# Altium schematic sheet Rectifier.SchDoc
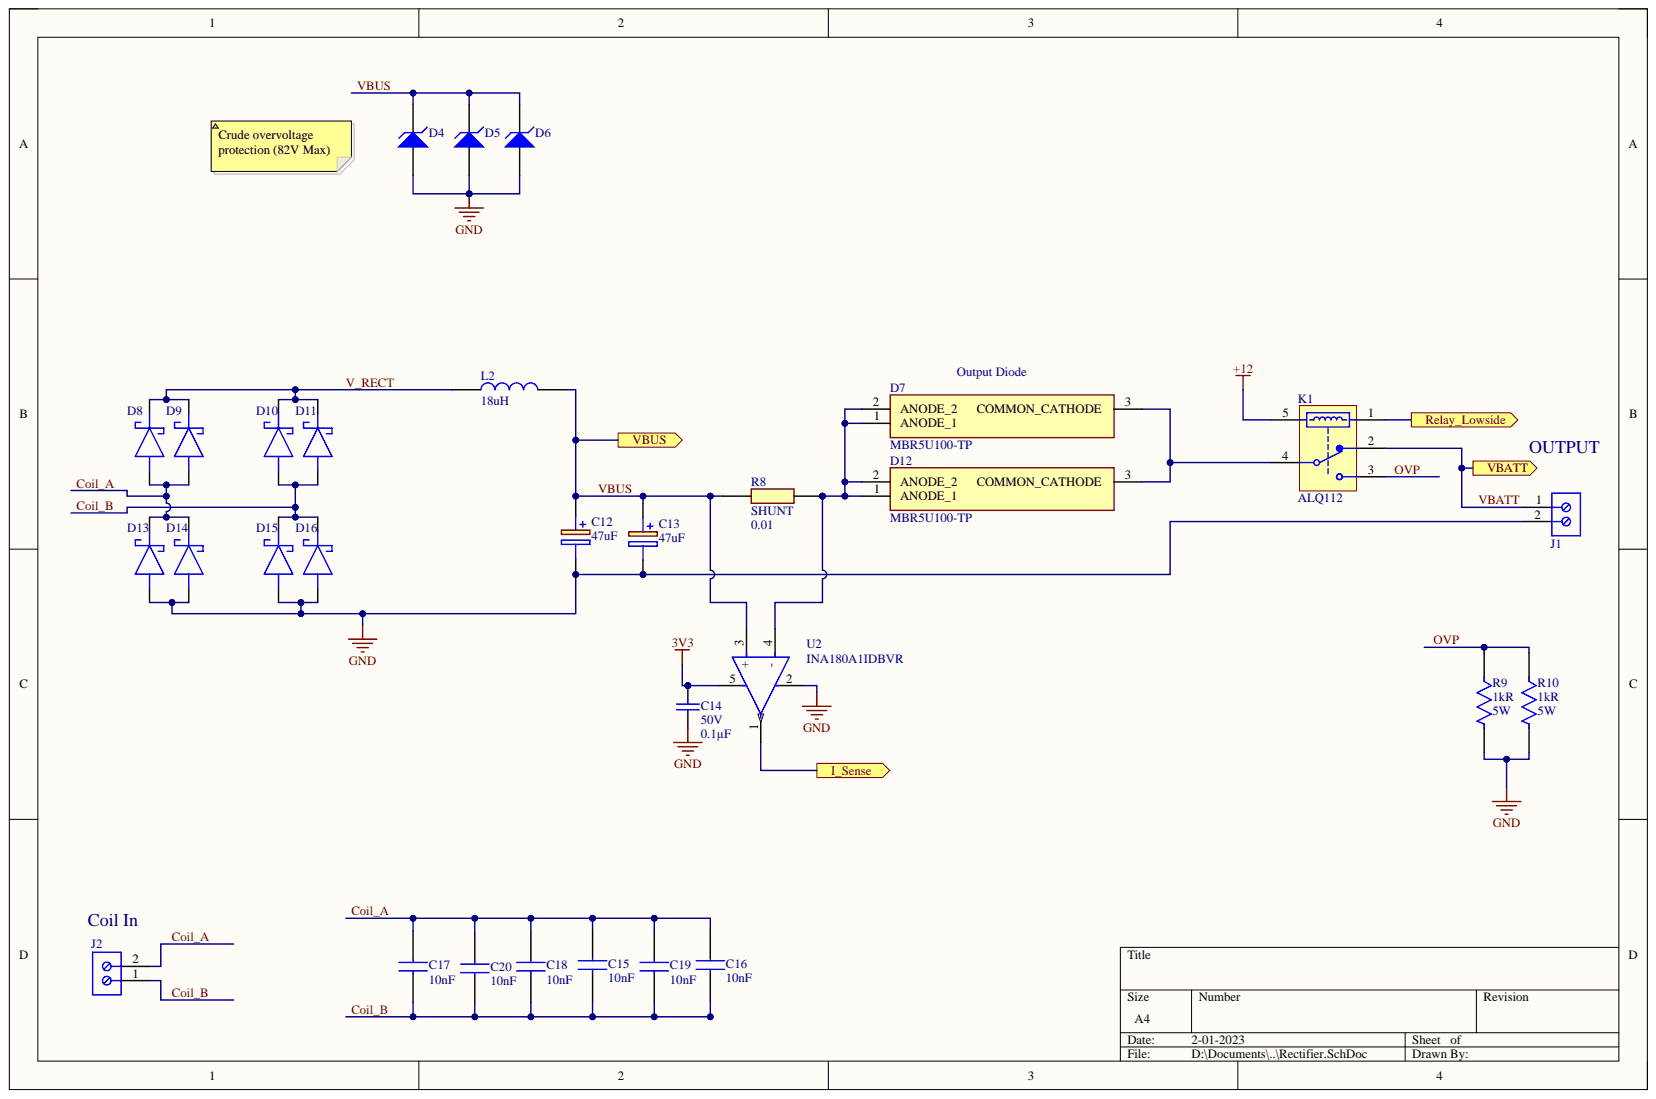
[diagram p0: Rectifier.SchDoc]

## Parts
C12  Capacitance: 47uF  [CAPAE1030X1080N]  (hidden comment: UCD1K470MNL1GS)
C13  Capacitance: 47uF  [CAPAE1030X1080N]  (hidden comment: UCD1K470MNL1GS)
C14  Capacitance: 0.1µF  Voltage - Rated: 50V  [CAP0805]  (hidden comment: C0805C104K5RACTU)
C15  Capacitance: 10nF  [CAPC3216X110N]  (hidden comment: C1206C103JAGECAUTO)
C16  Capacitance: 10nF  [CAPC3216X110N]  (hidden comment: C1206C103JAGECAUTO)
C17  Capacitance: 10nF  [CAPC3216X110N]  (hidden comment: C1206C103JAGECAUTO)
C18  Capacitance: 10nF  [CAPC3216X110N]  (hidden comment: C1206C103JAGECAUTO)
C19  Capacitance: 10nF  [CAPC3216X110N]  (hidden comment: C1206C103JAGECAUTO)
C20  Capacitance: 10nF  [CAPC3216X110N]  (hidden comment: C1206C103JAGECAUTO)
D4  1SMB5947BT3G  [ONSC-SMB-2-403A-03_H_V]  (value not drawn)
D5  1SMB5947BT3G  [ONSC-SMB-2-403A-03_H_V]  (value not drawn)
D6  1SMB5947BT3G  [ONSC-SMB-2-403A-03_H_V]  (value not drawn)
D7  MBR5U100-TP  [MBR5U100TP]
D8  STPS4S200UFN  [SODFL5436X110N]  (value not drawn)
D9  STPS4S200UFN  [SODFL5436X110N]  (value not drawn)
D10  STPS4S200UFN  [SODFL5436X110N]  (value not drawn)
D11  STPS4S200UFN  [SODFL5436X110N]  (value not drawn)
D12  MBR5U100-TP  [MBR5U100TP]
D13  STPS4S200UFN  [SODFL5436X110N]  (value not drawn)
D14  STPS4S200UFN  [SODFL5436X110N]  (value not drawn)
D15  STPS4S200UFN  [SODFL5436X110N]  (value not drawn)
D16  STPS4S200UFN  [SODFL5436X110N]  (value not drawn)
J1  691137710002  [691137710002]  (value not drawn)
J2  691137710002  [691137710002]  (value not drawn)
K1  ALQ112  [PNSC-LQ-5-2000x1000x1600_V]
L2  Inductance: 18uH  [SDR1307-220ML]  (hidden comment: SDR1307-180ML)
R8  SHUNT  Resistance: 0.01  [RESC6434X90N]
R9  Max Power Dissipation: 5W  Resistance: 1kR  [FP-SMF5-MFG]  (hidden comment: SMF51K0JT)
R10  Max Power Dissipation: 5W  Resistance: 1kR  [FP-SMF5-MFG]  (hidden comment: SMF51K0JT)
U2  INA180A1IDBVR  [FP-DBV0005A-IPC_A]

## Ports
I_Sense, Relay_Lowside, VBATT, VBUS

## Nets
3V3: C14.2 U2.5
+12: K1.5
GND: C12.2 C13.2 C14.1 D4.2 D5.2 D6.2 D13.2 D14.2 D15.2 D16.2 J1.2 R9.2 R10.2 U2.2
Coil_A: C15.1 C16.1 C17.1 C18.1 C19.1 C20.1 D8.2 D9.2 D13.1 D14.1 J2.2
Coil_B: C15.2 C16.2 C17.2 C18.2 C19.2 C20.2 D10.2 D11.2 D15.1 D16.1 J2.1
I_Sense: U2.1 ; port I_Sense
OVP: K1.3 R9.1 R10.1
Relay_Lowside: K1.1 ; port Relay_Lowside
V_RECT: D8.1 D9.1 D10.1 D11.1 L2.1
VBATT: J1.1 K1.2 ; port VBATT
VBUS: C12.1 C13.1 D4.1 D5.1 D6.1 L2.2 R8.1 U2.3(+) ; port VBUS
NetD7_1: D7.1 D7.2 D12.1 D12.2 R8.2 U2.4(-)
NetD7_3: D7.3 D12.3 K1.4

## Library data of the parts
C12 C13 = UCD1K470MNL1GS: Capacitor Polarised; Manufacturer_Name: Nichicon; Arrow Part Number: UCD1K470MNL1GS; Arrow Price/Stock: https://www.arrow.com/en/products/ucd1k470mnl1gs/nichicon; Component Kind: Standard; Datasheet Link: https://www.arrow.com/en/products/ucd1k470mnl1gs/nichicon; Height: 10.8mm; Manufacturer_Part_Number: UCD1K470MNL1GS; Mouser Part Number: 647-UCD1K470MNL1GS; Mouser Price/Stock: https://www.mouser.co.uk/ProductDetail/Nichicon/UCD1K470MNL1GS?qs=c0uEScvPYN07T8BStUenxw%3D%3D
C14 = C0805C104K5RACTU: CAP CER 0.1UF 50V X7R 0805; Tolerance: ±10%; Component Kind: Standard; Library Name: Capacitors.IntLib; Package / Case: 0805; Supplier 1: Digi-Key; Supplier Part Number 1: 399-1170-1-ND; Temperature Coefficient: X7R
C15-C20 = C1206C103JAGECAUTO: Capacitor; Manufacturer_Name: KEMET; Arrow Part Number: C1206C103JAGECAUTO; Arrow Price/Stock: https://www.arrow.com/en/products/c1206c103jagecauto/kemet-corporation?region=nac; Component Kind: Standard; Datasheet Link: https://content.kemet.com/datasheets/KEM_C1091_C0G_ESD.pdf; Height: 1.1mm; Manufacturer_Part_Number: C1206C103JAGECAUTO; Mouser Part Number: 80-C1206C103JAGECAUT; Mouser Price/Stock: https://www.mouser.co.uk/ProductDetail/KEMET/C1206C103JAGECAUTO?qs=MLItCLRbWsxJM3Q8yxQv7A%3D%3D
D4-D6 = CMP-1060-00168-1: 3 W Zener Voltage Regulator, 2-Pin SMB, Pb-Free, Tape and Reel; Manufacturer: On Semiconductor; VZ Typ (V): 82; Component Kind: Standard; ComponentLink1Description: Manufacturer URL; ComponentLink1URL: http://www.onsemi.com/; ComponentLink2Description: Datasheet; ComponentLink2URL: http://www.onsemi.com/pub_link/Collateral/1SMB5913BT3-D.PDF; ComponentLink3Description: Package Specification; ComponentLink3URL: http://www.onsemi.com/pub_link/Collateral/1SMB5913BT3-D.PDF; DatasheetVersion: Rev. 8; Library Name: Altium Content Vault; Mounting Technology: Surface Mount; PackageDescription: 2-Pin Small Molded Package, J-Bend Lead, Body 4.33 x 3.625 mm; PackageReference: SMB-2-403A-03_H; PackageVersion: Rev. H, 05/2011; Packing: Tape and Reel; PartNumber: 1SMB5947BT3G; RoHS: Pb-Free
D7 D12 = MBR5U100-TP: Schottky Diode; Manufacturer_Name: Micro Commercial Components (MCC); Component Kind: Standard; Datasheet Link: https://www.mccsemi.com/pdf/Products/MBR5U100(TO-277).pdf; Height: 1.2mm; Manufacturer_Part_Number: MBR5U100-TP; Library Name: WPT_Receiver_lib.SchLib
D8-D11 D13-D16 = STPS4S200UFN: Schottky Diode; Manufacturer_Name: STMicroelectronics; Arrow Part Number: STPS4S200UFN; Arrow Price/Stock: https://www.arrow.com/en/products/stps4s200ufn/stmicroelectronics?region=europe; Component Kind: Standard; Datasheet Link: https://www.st.com/resource/en/datasheet/stps4s200.pdf; Height: 1.1mm; Manufacturer_Part_Number: STPS4S200UFN; Mouser Part Number: 511-STPS4S200UFN; Mouser Price/Stock: https://www.mouser.co.uk/ProductDetail/STMicroelectronics/STPS4S200UFN?qs=xZ%2FP%252Ba9zWqZ%2F%2FCgto3MYYA%3D%3D
J1 J2 = CMP-1502-03324-1: Series 1377 - 5.00 mm Horizontal Entry with Pressure Clamp WR-TBL, 2 pin; Manufacturer: Wurth Elektronik; Pin Number: 2; Pitch: 5.00mm; Component Kind: Standard; Datasheet URL: http://katalog.we-online.de/pbs/datasheet/691137710002.pdf; Library Name: Altium Content Vault; Manufacturer URL: http://www.we-online.de; Mounting Technology: THT; Operating Temperature: -30to120degC; Package Reference: 691137710002; Packaging: Box; Part Number: 691137710002; Publisher: WurthElektronik
K1 = CMP-1503-00193-1: LQ Relays, 12 V Coil Voltage, 400 mW Nominal Operating Power, -40 to 85 degC, 5-Pin LQ, Carton, Case, RoHS; Manufacturer: Panasonic; Coil Voltage: 12 V; Electrical Life: 5x10^4; Max Switching Current: 10 A (N.O.), 3 A (N.C.); Max Switching Voltage: 250 V AC; Mechanical Life(Min.operation): 1x10^7; Nominal Operating Power: 400 mW; Pb-Free: True; Component Kind: Standard; ComponentLink1Description: Manufacturer URL; ComponentLink1URL: http://www3.panasonic.biz/ac/ae/index.jsp; ComponentLink2Description: Datasheet; ComponentLink2URL: http://www3.panasonic.biz/ac/e_download/control/relay/power/catalog/mech_eng_lq.pdf?f_cd=401220; ComponentLink3Description: Package Specification; ComponentLink3URL: http://www3.panasonic.biz/ac/e_download/control/relay/power/catalog/mech_eng_lq.pdf?f_cd=401220; DatasheetVersion: 201410-T; Library Name: Altium Content Vault; Mounting Technology: Through Hole; PackageDescription: 5-Pin Through Hole Terminal Package, Body 20 x 10 mm; PackageReference: LQ-5-2000x1000x1600; PackageVersion: ASCTB92E, 10/2014; Packing: Carton, Case; PartNumber: ALQ112; RoHS: True; Series: LQ Relays
L2 = SDR1307-180ML: Inductor; Manufacturer_Name: Bourns; Arrow Part Number: SDR1307-180ML; Arrow Price/Stock: https://www.arrow.com/en/products/sdr1307-180ml/bourns?region=nac; Component Kind: Standard; Datasheet Link: https://www.bourns.com/docs/Product-Datasheets/SDR1307.pdf; Manufacturer_Part_Number: SDR1307-180ML; Mouser Part Number: 652-SDR1307-180ML; Mouser Price/Stock: https://www.mouser.co.uk/ProductDetail/Bourns/SDR1307-180ML?qs=meVWcSOgEr47xBfFHZvpmA%3D%3D
R8 = CRA2512-FZ-R010ELF: Resistor; Manufacturer_Name: Bourns; Arrow Part Number: CRA2512-FZ-R010ELF; Arrow Price/Stock: https://www.arrow.com/en/products/cra2512-fz-r010elf/bourns; Component Kind: Standard; Datasheet Link: http://www.bourns.com/PDFs/CRA.pdf; Height: 0.9mm; Manufacturer_Part_Number: CRA2512-FZ-R010ELF; Mouser Part Number: 652-CRA2512FZR010ELF; Mouser Price/Stock: https://www.mouser.co.uk/ProductDetail/Bourns/CRA2512-FZ-R010ELF?qs=WqWCsLCZBkoNPiv%2FXVnYvw%3D%3D
R9 R10 = CMP-03211-011405-1: RES SMD 1K OHM 5% 5W 5329; Number of Resistors: 1; Temperature Coefficient: 100ppm/°C; Voltage Rating: 500V; Case Code (Imperial): 5328; Case/Package: SMD/SMT; Component Kind: Standard; Depth: 7.3mm; ELV: Compliant; Features: Flame Retardant Coating; Height: 6.8mm; Length: 13.5mm; Library Name: Altium Content Vault; Max Operating Temperature: 280°C; Min Operating Temperature: -80°C; Mount: Surface Mount; Number of Terminations: 2; Packaging: Tape and Reel; Power Rating: 5W; Radiation Hardening: No; Resistance Tolerance: 5%; Resistor Type: Power resistor; RoHS Compliant: Yes; Series: SM; Technology: METAL FILM; Termination: Solder; Tolerance: 5%; Voltage Rating (DC): 500V; Width: 7.3mm
U2 = CMP-04895-000140-1: IC CURR SENSE 1 CIRCUIT SOT23-5; -3db Bandwidth: 350kHz; Input Bias Current: 100nA; Input Offset Voltage (Vos): 100uV; Number of Circuits: 1; Operating Supply Current: 260uA; Slew Rate: 2V/µs; Case/Package: SOT-23-5; Component Kind: Standard; Library Name: Altium Content Vault; Max Operating Temperature: 125°C; Min Operating Temperature: -40°C; Mount: Surface Mount; Packaging: Tape and Reel
